# Altium schematic sheet 06_LVDC_Protection.SchDoc
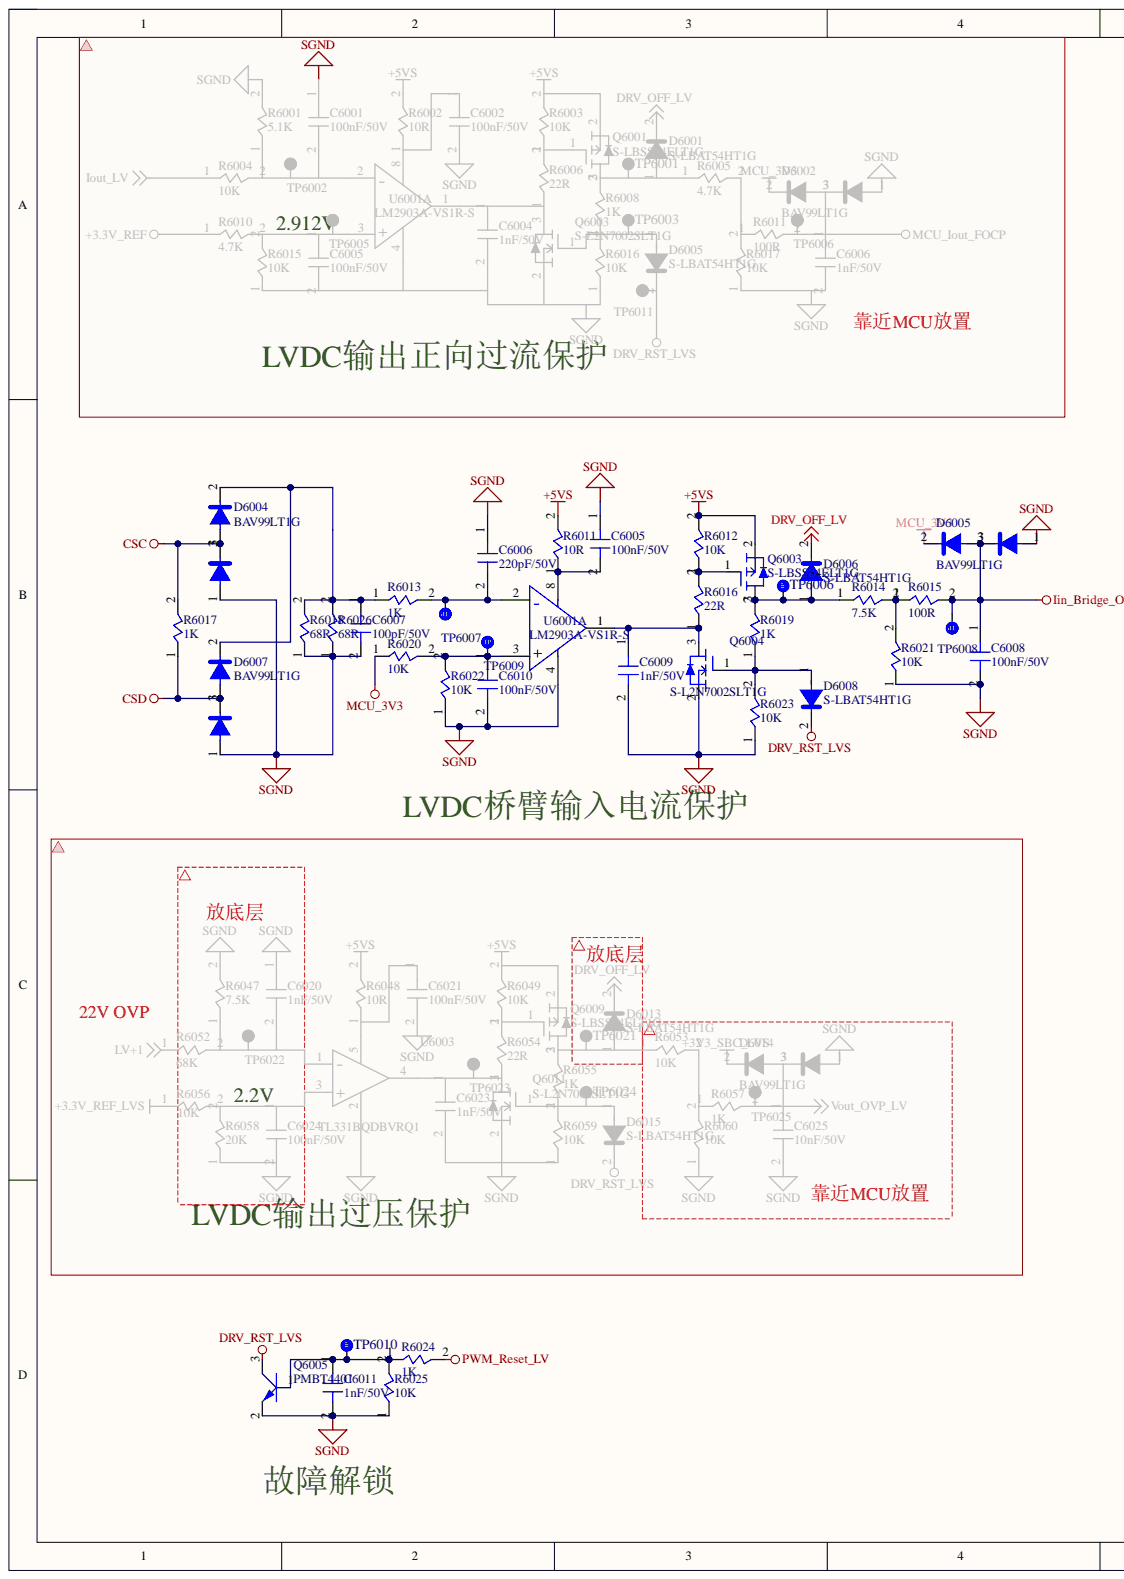
[diagram p0: 06_LVDC_Protection.SchDoc, left]
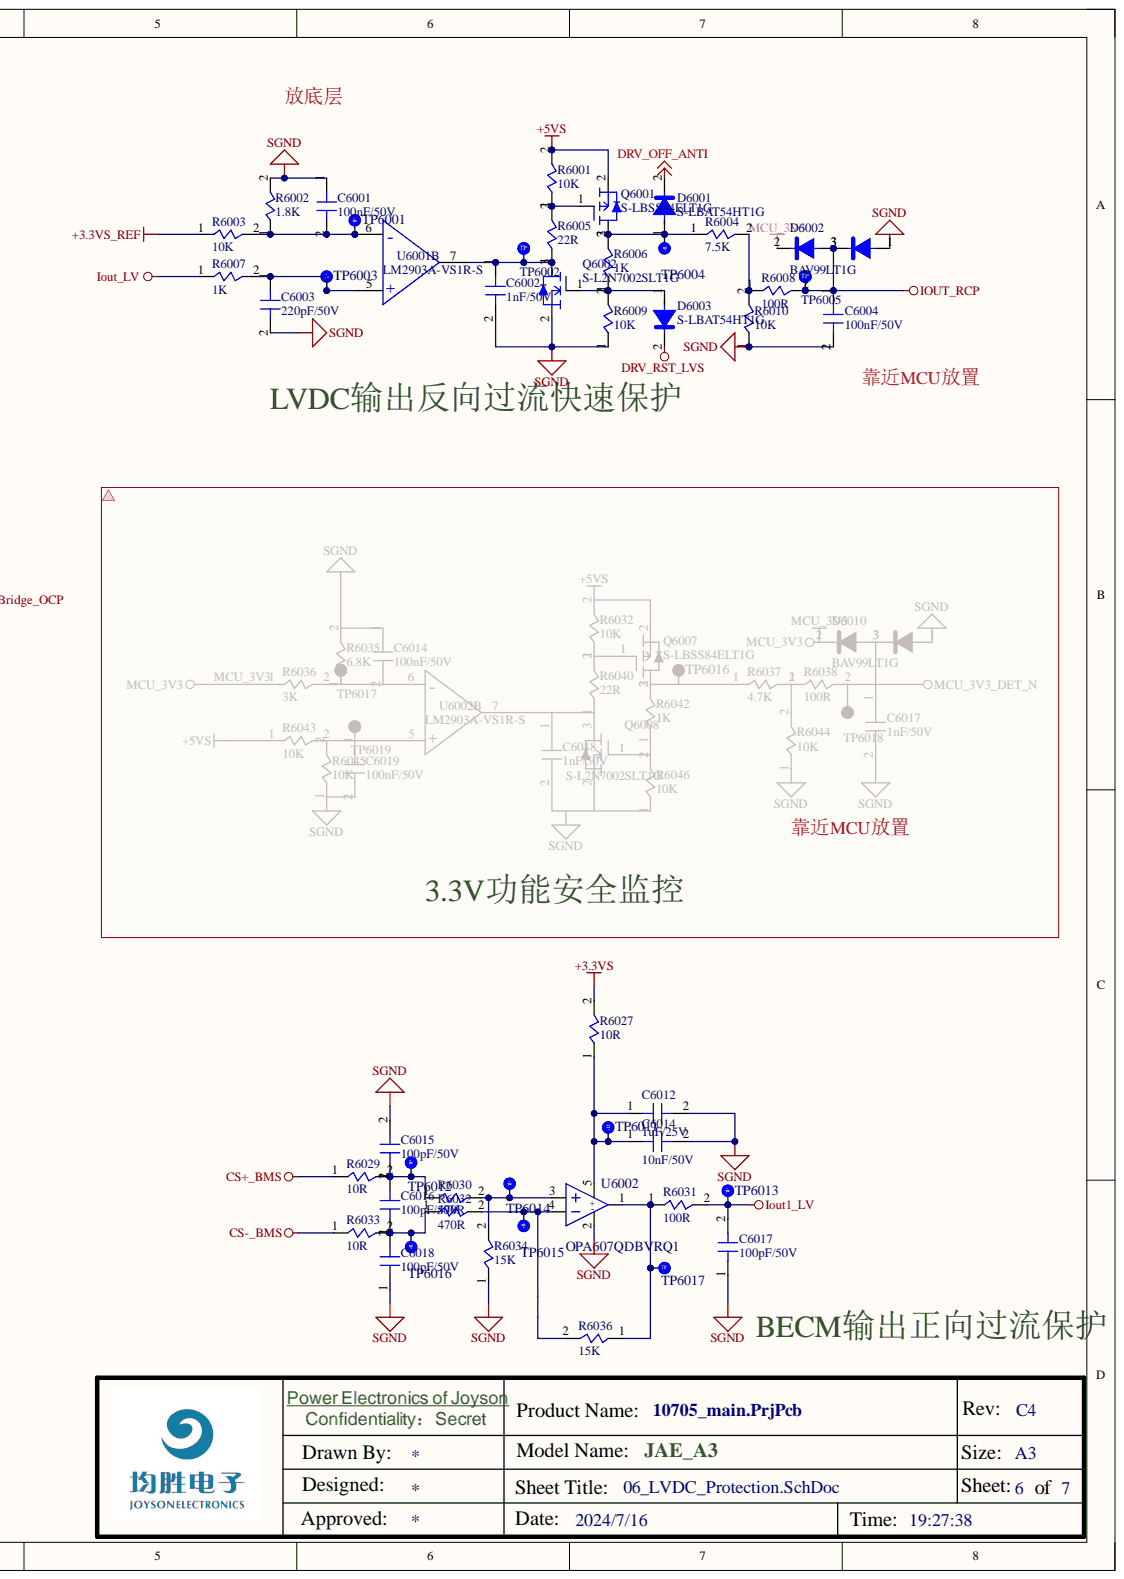
[diagram p1: 06_LVDC_Protection.SchDoc, right]

## Parts
C6001  100nF  value 100nF/50V  [C-0402]
C6002  1nF  value 1nF/50V  [C-0402]
C6003  220pF  value 220pF/50V  [C-0402]
C6004  100nF  value 100nF/50V  [C-0402]
C6005  100nF  value 100nF/50V  [C-0402]
C6006  220pF  value 220pF/50V  [C-0402]
C6007  100pF  value 100pF/50V  [C-0402]
C6008  100nF  value 100nF/50V  [C-0402]
C6009  1nF  value 1nF/50V  [C-0402]
C6010  100nF  value 100nF/50V  [C-0402]
C6011  1nF  value 1nF/50V  [C-0402]
C6012  1uF  value 1uF/25V  [C-0603]
C6014  10nF  value 10nF/50V  [C-0402]
C6015  100pF  value 100pF/50V  [C-0402]
C6016  100pF  value 100pF/50V  [C-0402]
C6017  100pF  value 100pF/50V  [C-0402]
C6018  100pF  value 100pF/50V  [C-0402]
D6001  S-LBAT54HT1G  [SOD-323]
D6002  BAV99LT1G  [SOT-23]
D6003  S-LBAT54HT1G  [SOD-323]
D6004  BAV99LT1G  [SOT-23]
D6005  BAV99LT1G  [SOT-23]
D6006  S-LBAT54HT1G  [SOD-323]
D6007  BAV99LT1G  [SOT-23]
D6008  S-LBAT54HT1G  [SOD-323]
Q6001  S-LBSS84ELT1G  [SOT-23]
Q6002  S-L2N7002SLT1G  [SOT-23]
Q6003  S-LBSS84ELT1G  [SOT-23]
Q6004  S-L2N7002SLT1G  [SOT-23]
Q6005  PMBT4401  [SOT-23]
R6001  10K  [R-0402]
R6002  1.8K  [R-0402]
R6003  10K  [R-0402]
R6004  7.5K  [R-0402]
R6005  22R  [R-0402]
R6006  1K  [R-0402]
R6007  1K  [R-0402]
R6008  100R  [R-0402]
R6009  10K  [R-0402]
R6010  10K  [R-0402]
R6011  10R  [R-0603]
R6012  10K  [R-0402]
R6013  1K  [R-0402]
R6014  7.5K  [R-0402]
R6015  100R  [R-0402]
R6016  22R  [R-0402]
R6017  1K  [R-1206]
R6018  68R  [R-0603]
R6019  1K  [R-0402]
R6020  10K  [R-0402]
R6021  10K  [R-0402]
R6022  10K  [R-0402]
R6023  10K  [R-0402]
R6024  1K  [R-0402]
R6025  10K  [R-0402]
R6026  68R  [R-0603]
R6027  10R  [R-0402]
R6029  10R  [R-0402]
R6030  470R  [R-0402]
R6031  100R  [R-0402]
R6032  470R  [R-0402]
R6033  10R  [R-0402]
R6034  15K  [R-0402]
R6036  15K  [R-0402]
TP6001  TEST PAD-0.7  [STP-0.7M]  (value not drawn)  (no BOM)
TP6002  TP1.0  [TP1.0]  (value not drawn)  (no BOM)
TP6003  TEST PAD-0.7  [STP-0.7M]  (value not drawn)  (no BOM)
TP6004  TEST PAD-0.7  [STP-0.7M]  (value not drawn)  (no BOM)
TP6005  TP1.0  [TP1.0]  (value not drawn)  (no BOM)
TP6006  TEST PAD-0.7  [STP-0.7M]  (value not drawn)  (no BOM)
TP6007  TP1.0  [TP1.0]  (value not drawn)  (no BOM)
TP6008  TP1.0  [TP1.0]  (value not drawn)  (no BOM)
TP6009  TP1.0  [TP1.0]  (no BOM)
TP6010  TEST PAD-0.7  [STP-0.7M]  (value not drawn)  (no BOM)
TP6011  TEST PAD-0.7  [TP1.0]  (value not drawn)  (no BOM)
TP6012  TEST PAD-0.7  [STP-0.7M]  (value not drawn)  (no BOM)
TP6013  TEST PAD-0.7  [TP1.0]  (value not drawn)  (no BOM)
TP6014  TEST PAD-0.7  [STP-0.7M]  (value not drawn)  (no BOM)
TP6015  TEST PAD-0.7  [STP-0.7M]  (value not drawn)  (no BOM)
TP6016  TEST PAD-0.7  [STP-0.7M]  (value not drawn)  (no BOM)
TP6017  TEST PAD-0.7  [STP-0.7M]  (value not drawn)  (no BOM)
U6001  LM2903A-VS1R-S  [VSSOP-8]  (parts A,B)
U6002  OPA607QDBVRQ1  [SOT-23-5]

## Nets
+3.3VS: R6027.2
+3.3VS_REF: R6003.1
+5VS: Q6001.2 Q6003.2 R6001.2 R6011.2 R6012.2
CS+_BMS: R6029.1
CS-_BMS: R6033.1
CSC: D6004.3 R6017.2
CSD: D6007.3 R6017.1
DRV_OFF_ANTI: D6001.2
DRV_OFF_LV: D6006.2
DRV_RST_LVS: D6003.2 D6008.2 Q6005.3
Iin_Bridge_OCP: C6008.1 D6005.3 R6015.2 TP6008.1
Iout1_LV: C6017.2 R6031.2 TP6013.1
Iout_LV: R6007.1
IOUT_RCP: C6004.1 D6002.3 R6008.2 TP6005.1
MCU_3V3: D6002.2 D6005.2 R6020.1
PWM_Reset_LV: R6024.2
SGND: C6001.1 C6002.2 C6003.2 C6004.2 C6005.1 C6006.1 C6007.2 C6008.2 C6009.2 C6010.2 C6011.2 C6012.2 C6014.2 C6015.2 C6017.1 C6018.1 D6002.1 D6004.1 D6005.1 D6007.1 Q6002.2 Q6004.2 Q6005.2 R6002.2 R6009.1 R6010.1 R6018.1 R6021.1 R6022.1 R6023.1 R6025.1 R6026.1 R6034.1 U6001.4 U6002.2(+)
NetC6001_2: C6001.2 R6002.1 R6003.2 TP6001.1 U6001.6(-)
NetC6002_1: C6002.1 Q6002.3 R6005.1 TP6002.1 U6001.7
NetC6003_1: C6003.1 R6007.2 TP6003.1 U6001.5(+)
NetC6005_2: C6005.2 R6011.1 U6001.8
NetC6006_2: C6006.2 R6013.2 TP6007.1 U6001.2(-)
NetC6007_1: C6007.1 D6004.2 D6007.2 R6013.1 R6018.2 R6026.2
NetC6009_1: C6009.1 Q6004.3 R6016.1 U6001.1
NetC6010_1: C6010.1 R6020.2 R6022.2 TP6009.1 U6001.3(+)
NetC6011_1: C6011.1 Q6005.1 R6024.1 R6025.2 TP6010.1
NetC6012_1: C6012.1 C6014.1 R6027.1 TP6011.1 U6002.5
NetC6015_1: C6015.1 C6016.2 R6029.2 R6030.1 TP6012.1
NetC6016_1: C6016.1 C6018.2 R6032.1 R6033.2 TP6016.1
NetD6001_1: D6001.1 Q6001.3 R6004.1 R6006.2 TP6004.1
NetD6003_1: D6003.1 Q6002.1 R6006.1 R6009.2
NetD6006_1: D6006.1 Q6003.3 R6014.1 R6019.2 TP6006.1
NetD6008_1: D6008.1 Q6004.1 R6019.1 R6023.2
NetQ6001_1: Q6001.1 R6001.1 R6005.2
NetQ6003_1: Q6003.1 R6012.1 R6016.2
NetR6004_2: R6004.2 R6008.1 R6010.2
NetR6014_2: R6014.2 R6015.1 R6021.2
NetR6030_2: R6030.2 R6034.2 TP6014.1 U6002.3
NetR6031_1: R6031.1 R6036.1 TP6017.1 U6002.1
NetR6032_2: R6032.2 R6036.2 TP6015.1 U6002.4

## Library data of the parts
C6001 C6004 C6005 C6008 C6010 = 1101012028-00: 贴片电容封装; Brand: YAGEO; Database Table Name: Capacitor-SMD; Grade: 汽车级; Material Name: Capacitor; SAP Number: 09701-715/0000; Spec/Modle: Capacitor-MLCC-0402-X7R-±10%-50V-100nF; Temprature: -55℃ to +125℃; Type: 贴片; Vendor No: AC0402KRX7R9BB104; Component Kind: Standard; Library Name: Database_Libs1.DbLib; Material No: 1101012028-00; Value2: 100nF
C6002 C6009 C6011 = 1101012010-00: 贴片电容封装; Brand: YAGEO; Database Table Name: Capacitor-SMD; Grade: 汽车级; Material Name: Capacitor; SAP Number: 09801-025/0000; Spec/Modle: Capacitor-MLCC-0402-X7R-±10%-50V-1nF; Temprature: -55℃ to +125℃; Type: 贴片; Vendor No: AC0402KRX7R9BB102; Component Kind: Standard; Library Name: Database_Libs1.DbLib; Material No: 1101012010-00; Value2: 1nF
C6003 C6006 = 1101012003-00: 贴片电容封装; Brand: YAGEO; Database Table Name: Capacitor-SMD; Grade: 汽车级; Material Name: Capacitor; SAP Number: 11003-598/0000; Spec/Modle: Capacitor-MLCC-0402-X7R-±10%-50V-220pF; Temprature: -55℃ to +125℃; Type: 贴片; Vendor No: AC0402KRX7R9BB221; Component Kind: Standard; Library Name: Database_Libs1.DbLib; Material No: 1101012003-00; Value2: 220pF
C6007 C6015-C6018 = 1101012001-00: 贴片电容封装; Brand: YAGEO; Database Table Name: Capacitor-SMD; Grade: 汽车级; Material Name: Capacitor; Spec/Modle: Capacitor-MLCC-0402-X7R-±10%-50V-100pF; Temprature: -55℃ to +125℃; Type: 贴片; Vendor No: AC0402KRX7R9BB101; Component Kind: Standard; Library Name: Database_Libs1.DbLib; Material No: 1101012001-00; Value2: 100pF
C6012 = 1101022035-00: 贴片电容封装; Brand: YAGEO; Database Table Name: Capacitor-SMD; Grade: 汽车级; Material Name: Capacitor; Spec/Modle: Capacitor-MLCC-0603-X7R-±10%-25V-1uF; Temprature: -55℃ to +125℃; Type: 贴片; Vendor No: AC0603KPX7R8BB105; Component Kind: Standard; Library Name: Database_Libs1.DbLib; Material No: 1101022035-00; Value2: 1uF
C6014 = 1101012019-00: 贴片电容封装; Brand: YAGEO; Database Table Name: Capacitor-SMD; Grade: 汽车级; Material Name: Capacitor; SAP Number: 09701-712/0000; Spec/Modle: Capacitor-MLCC-0402-X7R-±10%-50V-10nF; Temprature: -55℃ to +125℃; Type: 贴片; Vendor No: AC0402KRX7R9BB103; Component Kind: Standard; Library Name: Database_Libs1.DbLib; Material No: 1101012019-00; Value2: 10nF
D6001 D6003 D6006 D6008 = 1203020002-00: 二极管SMD-2P封装; Brand: LRC; Database Table Name: Diode; Grade: 工业级; SAP Number: 06005-408/0000; Spec/Modle: Diode-Schottky-SMD-SOD323-30V-200mA-mark(JV); Temprature: -55℃ to +125℃; Type: 贴片; Component Kind: Standard; Library Name: Database_Libs1.DbLib; Material Name: Diode; Material No: 1203020002-00; Value: S-LBAT54HT1G; Vendor No: S-LBAT54HT1G
D6002 D6004 D6005 D6007 = 1203060001-00: 二极管SOT23串联封装; Brand: onsemi; Database Table Name: Diode; Grade: 工业级; SAP Number: 06005-404/0000; Spec/Modle: Diode-Schottky-SOT23-100V-0.7A-mark(A7M); Temprature: -65℃ to +150℃; Type: 贴片; Component Kind: Standard; Library Name: Database_Libs1.DbLib; Material Name: Diode; Material No: 1203060001-00; Value: BAV99LT1G; Vendor No: BAV99LT1G
Q6001 Q6003 = 1305030001-00: PMOS管SOT23封装; Brand: LRC; Database Table Name: Transistor; Grade: 汽车级; Material Name: Mosfet; SAP Number: 06005-433/0000; Spec/Modle: Mosfet-PMOS-SOT23-(-60V)-(-0.13A)-5Ω-mark(PE); Temprature: -55℃ to +150℃; Type: 贴片; Component Kind: Standard; Library Name: Database_Libs1.DbLib; Material No: 1305030001-00; Value: S-LBSS84ELT1G; Vendor No: S-LBSS84ELT1G
Q6002 = 1304030001-00: NMOS管SOT23封装; Brand: LRC; Database Table Name: Transistor; Grade: 汽车级; Material Name: Mosfet; SAP Number: 06005-431/0000; Spec/Modle: Mosfet-NMOS-SOT23-60V-380mA-3.2Ω-mark(701); Temprature: -55℃ to +150℃; Type: 贴片; Component Kind: Standard; Library Name: Database_Libs1.DbLib; Material No: 1304030001-00; Value: S-L2N7002SLT1G; Vendor No: S-L2N7002SLT1G
Q6004 = 2N7002: Brand: LRC; Material Name: Mosfet; Material No: 2051100170-00; SAP Number: 06005-431/0000; Spec/Modle: SMD,TR,NMOS,SOT-23,380mA,60V,3.2Ω; Component Kind: Standard; Library Name: my lib.schlib; Vendor No: S-L2N7002SLT1G
Q6005 = 1301030001-00: NPN三极管SOT23封装; Brand: nexperia; Database Table Name: Transistor; Grade: 工业级; SAP Number: 06005-200/0000; Spec/Modle: Transistor-NPN-SOT23-40V-600mA-hfe(100->300)-mark(2X); Temprature: -65℃ to +150℃; Type: 贴片; Component Kind: Standard; Library Name: Database_Libs1.DbLib; Material Name: Transistor; Material No: 1301030001-00; Value: PMBT4401; Vendor No: PMBT4401
R6001 R6003 R6009 R6010 R6012 R6020-R6023 R6025 = 1001010457-00: 贴片电阻封装; Brand: YAGEO; Database Table Name: Resistor-SMD; Grade: 汽车级; Material Name: Resistor; SAP Number: 05544-477/0000; Spec/Modle: Resistor-SMD-0402-1/16W-±1%-±100ppm/℃-10KΩ; Temprature: -55℃ to +155℃; Vendor No: AC0402FR-0710KL; Component Kind: Standard; Library Name: Database_Libs1.DbLib; Material No: 1001010457-00; Type: 贴片; Value: 10K
R6002 = 1001010370-00: 贴片电阻封装; Brand: YAGEO; Database Table Name: Resistor-SMD; Grade: 汽车级; Material Name: Resistor; SAP Number: 05544-390/0000; Spec/Modle: Resistor-SMD-0402-1/16W-±1%-±100ppm/℃-1.8KΩ; Temprature: -55℃ to +155℃; Vendor No: AC0402FR-071K8L; Component Kind: Standard; Library Name: Database_Libs1.DbLib; Material No: 1001010370-00; Type: 贴片; Value: 1.8K
R6004 R6014 = 1001010443-00: 贴片电阻封装; Brand: YAGEO; Database Table Name: Resistor-SMD; Grade: 汽车级; Material Name: Resistor; SAP Number: 05544-463/0000; Spec/Modle: Resistor-SMD-0402-1/16W-±1%-±100ppm/℃-7.5KΩ; Temprature: -55℃ to +155℃; Vendor No: AC0402FR-077K5L; Component Kind: Standard; Library Name: Database_Libs1.DbLib; Material No: 1001010443-00; Type: 贴片; Value: 7.5K
R6005 R6016 = 1001010151-00: 贴片电阻封装; Brand: YAGEO; Database Table Name: Resistor-SMD; Grade: 汽车级; Material Name: Resistor; SAP Number: 05544-171/0000; Spec/Modle: Resistor-SMD-0402-1/16W-±1%-±100ppm/℃-22Ω; Temprature: -55℃ to +155℃; Vendor No: AC0402FR-0722RL; Component Kind: Standard; Library Name: Database_Libs1.DbLib; Material No: 1001010151-00; Type: 贴片; Value: 22R
R6006 R6007 R6013 R6019 R6024 = 1001010343-00: 贴片电阻封装; Brand: YAGEO; Database Table Name: Resistor-SMD; Grade: 汽车级; Material Name: Resistor; SAP Number: 05544-363/0000; Spec/Modle: Resistor-SMD-0402-1/16W-±1%-±100ppm/℃-1KΩ; Temprature: -55℃ to +155℃; Vendor No: AC0402FR-071KL; Component Kind: Standard; Library Name: Database_Libs1.DbLib; Material No: 1001010343-00; Type: 贴片; Value: 1K
R6008 R6015 R6031 = 1001010229-00: 贴片电阻封装; Brand: YAGEO; Database Table Name: Resistor-SMD; Grade: 汽车级; Material Name: Resistor; SAP Number: 05544-249/0000; Spec/Modle: Resistor-SMD-0402-1/16W-±1%-±100ppm/℃-100Ω; Temprature: -55℃ to +155℃; Vendor No: AC0402FR-07100RL; Component Kind: Standard; Library Name: Database_Libs1.DbLib; Material No: 1001010229-00; Type: 贴片; Value: 100R
R6011 = 1001020115-00: 贴片电阻封装; Brand: YAGEO; Database Table Name: Resistor-SMD; Grade: 汽车级; Material Name: Resistor; SAP Number: 05543-135/0000; Spec/Modle: Resistor-SMD-0603-1/10W-±1%-±200ppm/℃-10Ω; Temprature: -55℃ to +155℃; Vendor No: AC0603FR-0710RL; Component Kind: Standard; Library Name: Database_Libs1.DbLib; Material No: 1001020115-00; Type: 贴片; Value: 10R
R6017 = 1001040343-00: 贴片电阻封装; Brand: YAGEO; Database Table Name: Resistor-SMD; Grade: 汽车级; Material Name: Resistor; SAP Number: 05541-363/0000; Spec/Modle: Resistor-SMD-1206-1/4W-±1%-±100ppm/℃-1KΩ; Temprature: -55℃ to +155℃; Vendor No: AC1206FR-071KL; Component Kind: Standard; Library Name: Database_Libs1.DbLib; Material No: 1001040343-00; Type: 贴片; Value: 1K
R6018 R6026 = 1001020210-00: 贴片电阻封装; Brand: YAGEO; Database Table Name: Resistor-SMD; Grade: 汽车级; Material Name: Resistor; SAP Number: 05543-230/0000; Spec/Modle: Resistor-SMD-0603-1/10W-±1%-±100ppm/℃-68Ω; Temprature: -55℃ to +155℃; Vendor No: AC0603FR-0768RL; Component Kind: Standard; Library Name: Database_Libs1.DbLib; Material No: 1001020210-00; Type: 贴片; Value: 68R
R6027 R6029 R6033 = 1001010115-00: 贴片电阻封装; Brand: YAGEO; Database Table Name: Resistor-SMD; Grade: 汽车级; Material Name: Resistor; SAP Number: 05544-135/0000; Spec/Modle: Resistor-SMD-0402-1/16W-±1%-±200ppm/℃-10Ω; Temprature: -55℃ to +155℃; Vendor No: AC0402FR-0710RL; Component Kind: Standard; Library Name: Database_Libs1.DbLib; Material No: 1001010115-00; Type: 贴片; Value: 10R
R6030 R6032 = 1001010305-00: 贴片电阻封装; Brand: YAGEO; Database Table Name: Resistor-SMD; Grade: 汽车级; Material Name: Resistor; SAP Number: 05544-325/0000; Spec/Modle: Resistor-SMD-0402-1/16W-±1%-±100ppm/℃-470Ω; Temprature: -55℃ to +155℃; Vendor No: AC0402FR-07470RL; Component Kind: Standard; Library Name: Database_Libs1.DbLib; Material No: 1001010305-00; Type: 贴片; Value: 470R
R6034 R6036 = 1001010475-00: 贴片电阻封装; Brand: YAGEO; Database Table Name: Resistor-SMD; Grade: 汽车级; Material Name: Resistor; SAP Number: 05544-495/0000; Spec/Modle: Resistor-SMD-0402-1/16W-±1%-±100ppm/℃-15KΩ; Temprature: -55℃ to +155℃; Vendor No: AC0402FR-0715KL; Component Kind: Standard; Library Name: Database_Libs1.DbLib; Material No: 1001010475-00; Type: 贴片; Value: 15K
TP6002 TP6005 TP6007-TP6009 = TP: Library Name: my lib.schlib
U6001 = LM2903DR: Brand: 3PEAK; Material Name: IC; Material No: 2062200020-00; SAP Number: 06005-453/0000; Spec/Modle: SMD,IC,Comparator,MSOP-8; Component Kind: Standard; Library Name: my lib.schlib; Vendor No: LM2903A-VS1R-S
U6002 = IC_NCS325SN2T1G: Brand: TI; Material Name: IC; Material No: 2062000100-00; SAP Number: 06005-450/0000; Spec/Modle: SMD,IC, Amplifier,SOT-23(5),50MHz; Component Kind: Standard; Library Name: ICs.IntLib; Vendor No: OPA607QDBVRQ1
TP6001 TP6003 TP6004 TP6006 TP6010-TP6017 = TEST PAD-0.7: Library Name: Test Pad.SchLib
